# Altium schematic sheet CONTROL_MCU.SchDoc
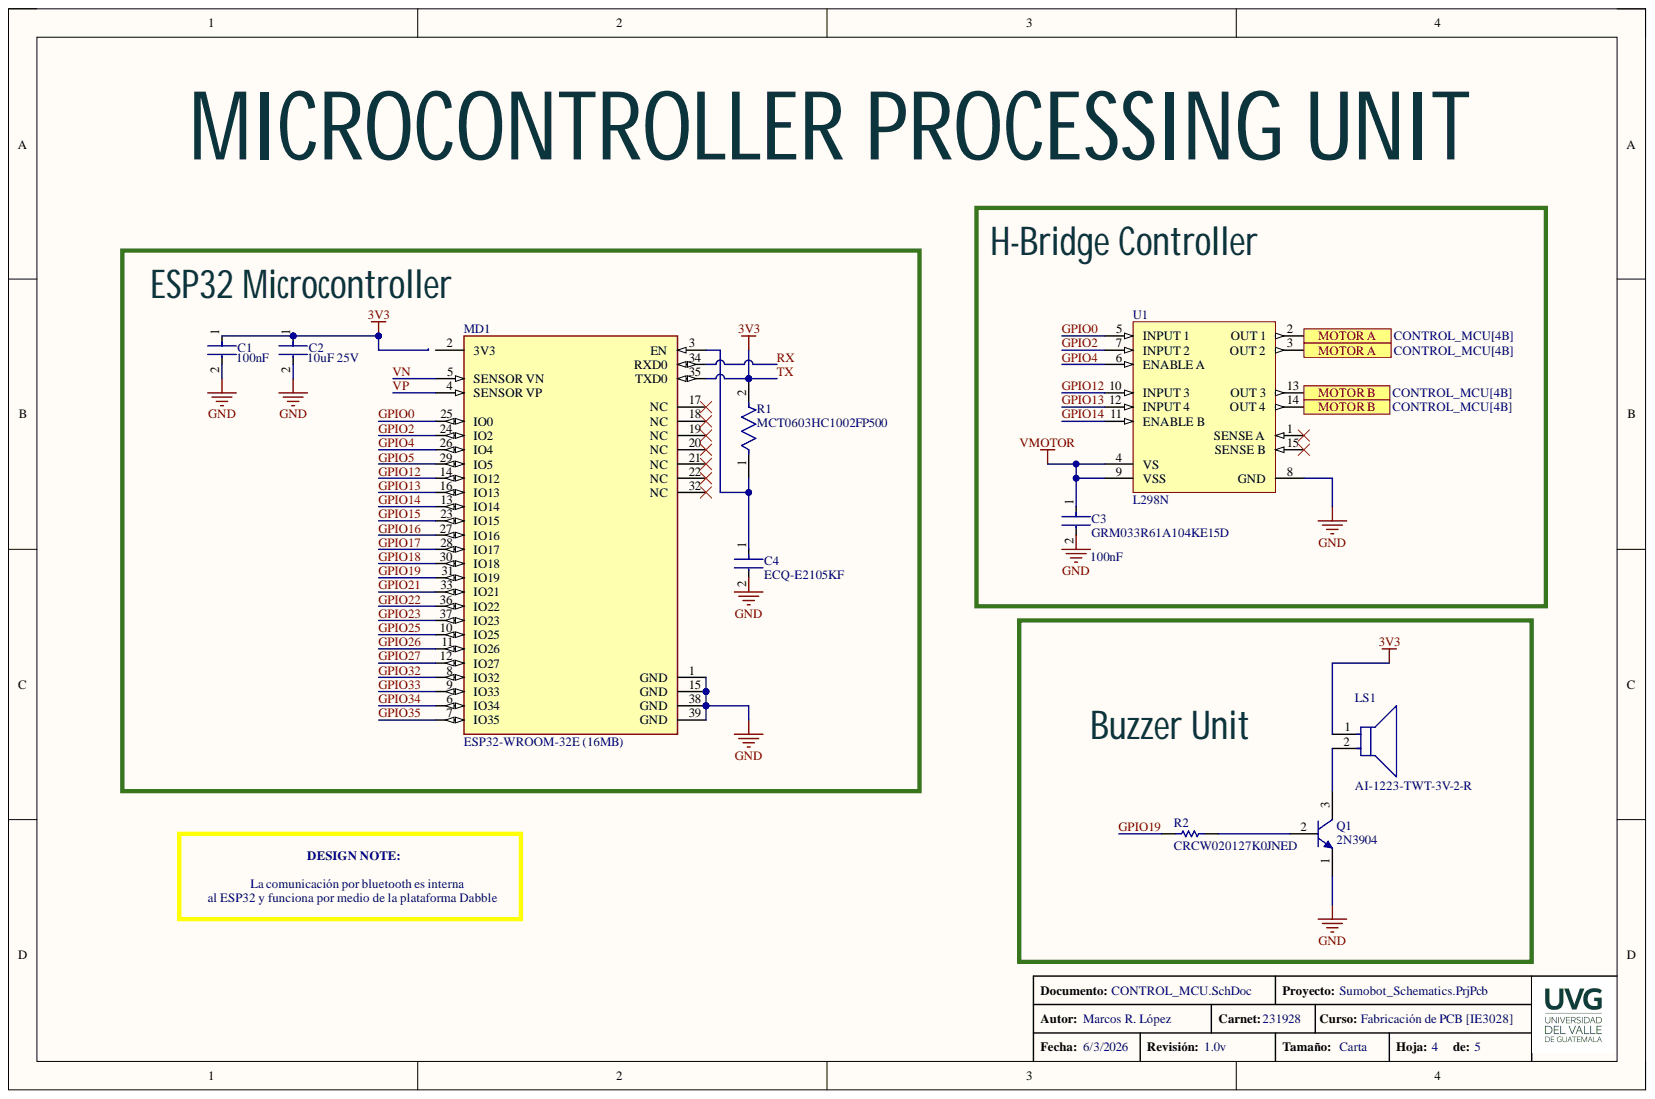
[diagram p0: CONTROL_MCU.SchDoc]

## Parts
C1  M033R61A104KE15D  Capacitance: 100nF  [FP-GRM033-0_03-IPC_C]
C2  value 10uF 25V  [FP-ZRB18A-0_2-MFG]  (hidden comment: ZRB18AR61E106KE01L)
C3  GRM033R61A104KE15D  Capacitance: 100nF  [FP-GRM033-0_03-IPC_C]
C4  ECQ-E2105KF  [FP-ECQ-E2105KF-MFG]
LS1  AI-1223-TWT-3V-2-R  [FP-AI-1223-TWT-3V-2-R-MFG]
MD1  ESP32-WROOM-32E (16MB)  [FP-ESP32-WROOM-32E_16MB-MFG]
Q1  2N3904  [ONSC-TO-92-3-29-11]
R1  MCT0603HC1002FP500  [FP-MCT0603-IPC_B]
R2  CRCW020127K0JNED  [RESC0603X28X15ML05T05]
U1  L298N  [STM-FM-15]

## Ports
MOTOR A, MOTOR B

## Nets
GND: C1.2 C2.2 C3.2 C4.2 MD1.1(GND) MD1.15(GND) MD1.38(GND) MD1.39(GND) Q1.1 U1.8(GND)
VMOTOR: C3.1 U1.4(VS) U1.9(VSS)
GPIO0: MD1.25(IO0) U1.5(INPUT_1)
GPIO2: MD1.24(IO2) U1.7(INPUT_2)
GPIO4: MD1.26(IO4) U1.6(ENABLE_A)
GPIO5: MD1.29(IO5)
GPIO12: MD1.14(IO12) U1.10(INPUT_3)
GPIO13: MD1.16(IO13) U1.12(INPUT_4)
GPIO14: MD1.13(IO14) U1.11(ENABLE_B)
GPIO15: MD1.23(IO15)
GPIO16: MD1.27(IO16)
GPIO17: MD1.28(IO17)
GPIO18: MD1.30(IO18)
GPIO19: MD1.31(IO19) R2.2
GPIO21: MD1.33(IO21)
GPIO22: MD1.36(IO22)
GPIO23: MD1.37(IO23)
GPIO25: MD1.10(IO25)
GPIO26: MD1.11(IO26)
GPIO27: MD1.12(IO27)
GPIO32: MD1.8(IO32)
GPIO33: MD1.9(IO33)
GPIO34: MD1.6(IO34)
GPIO35: MD1.7(IO35)
MOTOR A: U1.2(OUT_1) U1.3(OUT_2) ; port MOTOR A
MOTOR B: U1.13(OUT_3) U1.14(OUT_4) ; port MOTOR B
RX: MD1.34(RXD0)
TX: C1.1 C2.1 LS1.1 MD1.35(TXD0) R1.2
VN: MD1.5(SENSOR_VN)
VP: MD1.4(SENSOR_VP)
NetC4_1: C4.1 MD1.3(EN) R1.1
NetLS1_2: LS1.2 Q1.3
NetQ1_2: Q1.2 R2.1

Not connected: MD1 2(3V3) 17(NC) 18(NC) 19(NC) 20(NC) 21(NC) 22(NC) 32(NC); U1 1(SENSE_A) 15(SENSE_B)

## Library data of the parts
C1 C3 = CMP-2010-01264-2: Chip Multilayer Ceramic Capacitors for General Purpose, 0201, 0.10uF, X5R, 15%, 10%, 10V; Case Code (Imperial): 0201; Case Code (Metric): 0603; Case/Package: 0201; Component Kind: Standard; Depth: 0.3mm; Dielectric: X5R; Height: 0.3mm; Lead Free: Lead Free; Length: 0.6mm; Library Name: Altium Content Vault; Max Operating Temperature: 85°C; Min Operating Temperature: -55°C; Mount: Surface Mount; Package Quantity: 1; Packaging: Tape and Reel; Radiation Hardening: No; REACH SVHC: No SVHC; Termination: SMD/SMT; Thickness: 0.013inch; Tolerance: 10%; Voltage: 10V; Voltage Rating: 10V; Voltage Rating (DC): 10V; Weight: 6E-06oz; Width: 0.012inch
C2 = CMP-06035-063177-1: Low Acoustic Noise Chip Multilayer Ceramic Capacitors on Interposer Board for General Purpose ZRB Series 0603 Package 1.2mm X5R @ 15% 25Vdc 10uF @ 10%; Component Kind: Standard; Library Name: Altium Content Vault
C4 = CMP-00287-00215881-1: ECQ-E2105KF Cap Film 1uF 250V PET 10% (18.5 X 7.4 X 15mm) Radial 15mm 105 C Bulk; NexarPartId: 215881; Capacitance: 1µF; Dielectric Material: Polyester; Lead Diameter: 800µm; Lead Length: 20mm; Lead/Base Style: Straight; Case/Package: Radial; Component Kind: Standard; Contact Plating: Tin; Depth: 7.4mm; Dielectric: PET; Height: 15mm; Height - Seated (Max): 16.002mm; Lead Free: Lead Free; Lead Pitch: 15mm; Length: 18.5mm; Library Name: Altium Content Vault; Max Operating Temperature: 105°C; Min Operating Temperature: -40°C; Mount: Through Hole; Packaging: Bulk; Radiation Hardening: No; REACH SVHC: Unknown; RoHS: Compliant; Schedule B: 8532250070, 8532250070; Termination: Radial; Tolerance: 10%; Voltage Rating: 250V; Voltage Rating (AC): 250V; Voltage Rating (DC): 250V; Width: 7.3914mm
LS1 = CMP-103888-000003-1: BUZZER MAGNETIC 3V 12MM TH; Frequency: 2.3kHz; Input Type: DC; Max Supply Current: 30mA; Max Supply Voltage (DC): 4V; Min Supply Voltage (DC): 2V; Component Kind: Standard; Contact Plating: Tin; Diameter: 12mm; Height: 7.5mm; Library Name: Altium Content Vault; Max Operating Temperature: 70°C; Min Operating Temperature: -20°C; Mount: Through Hole; Nominal Supply Voltage (DC): 3V; Operating Supply Current: 30mA; Packaging: Tray; Sensing Method: Magnetic; Series: AI; Termination: PC Pins; Voltage Rating: 3V
MD1 = CMP-194065-000027-1: RX TXRX MOD WIFI TRACE ANT SMD; Component Kind: Standard; Library Name: Altium Content Vault
Q1 = CMP-2000-05562-1: General Purpose Transistor, NPN Silicon, 200 mA, 60 V, -55 to 150 degC, 3-Pin TO-92, RoHS, Bulk; Brand: ONSemiconductor; Collector- Base Voltage VCBO: 60V; Collector- Emitter Voltage VCEO Max: 40V; Collector-Emitter Saturation Voltage: 0.3V; Configuration: Single; DC Collector/Base Gain hfe Min: 60; Emitter- Base Voltage VEBO: 6V; Gain Bandwidth Product fT: 270MHz; Maximum DC Collector Current: 0.2A; Maximum Power Dissipation: 625mW; Component Kind: Standard; Datasheet URL: https://datasheet.ciiva.com/4725/2n3903-d-285841-4725808.pdf; Datasheet Version: Rev. 8, 08/2012; Factory Pack Quantity: 5000; Library Name: Altium Content Vault; Manufacturer: ONSemiconductor; Manufacturer URL: http://www.onsemi.com/; Maximum Operating Temperature: +150C; Minimum Operating Temperature: -65C; Mounting Style: ThroughHole; Mounting Technology: ThroughHole; Package / Case: TO-92-3(TO-226); Package Description: 3-Pin Transistor Outline, Body 4.825 x 3.685 mm, Pitch 1.27 mm; Package Reference: TO-92-3-29-11; Packaging: Bulk; Product Category: BipolarTransistors-BJT; RoHS: Details; Transistor Polarity: NPN
R1 = CMP-02407-001090-1: RES SMD 100 OHM 1% 1/8W 0603; Component Kind: Standard; Library Name: Altium Content Vault
R2 = CMP-2004-00383-1: Manufacturer: Vishay Dale; Component Kind: Standard; Library Name: Altium Content Vault; Part Number: CRCW020127K0JNED
U1 = CMP-2000-06526-1: Dual Full-Bridge Driver, 4.8 to 46 V, -40 to 150 degC, 15-Pin FM (MW 15L), RoHS, Tube; Manufacturer: STMicroelectronics; PartNumber: L298; Driver Case Style: Multiwatt; Motor Type: FullBridge; No. of Outputs: 4Outputs; No. of Pins: 15Pins; Output Current: 2A; Output Voltage: 46V; Supply Voltage Max: 7V; Supply Voltage Min: 4.5V; SVHC: NoSVHC(17-Dec-2015); Automotive Qualification Standard: -; Component Kind: Standard; Datasheet URL: https://datasheet.ciiva.com/15109/getdatasheetpartid-50682-15109332.pdf; Datasheet Version: 01/2000; Library Name: Altium Content Vault; Manufacturer URL: http://www.st.com/; Mounting Technology: ThroughHole; MSL: -; Operating Temperature Max: 130°C; Operating Temperature Min: -25°C; Package Description: 15-Pin Flange Mount, Body 5 x 19.6 mm, Pitch 1.27 mm; Package Reference: FM-15; Packaging: Each; Product Range: -
